# Altium schematic sheet I2C.SchDoc
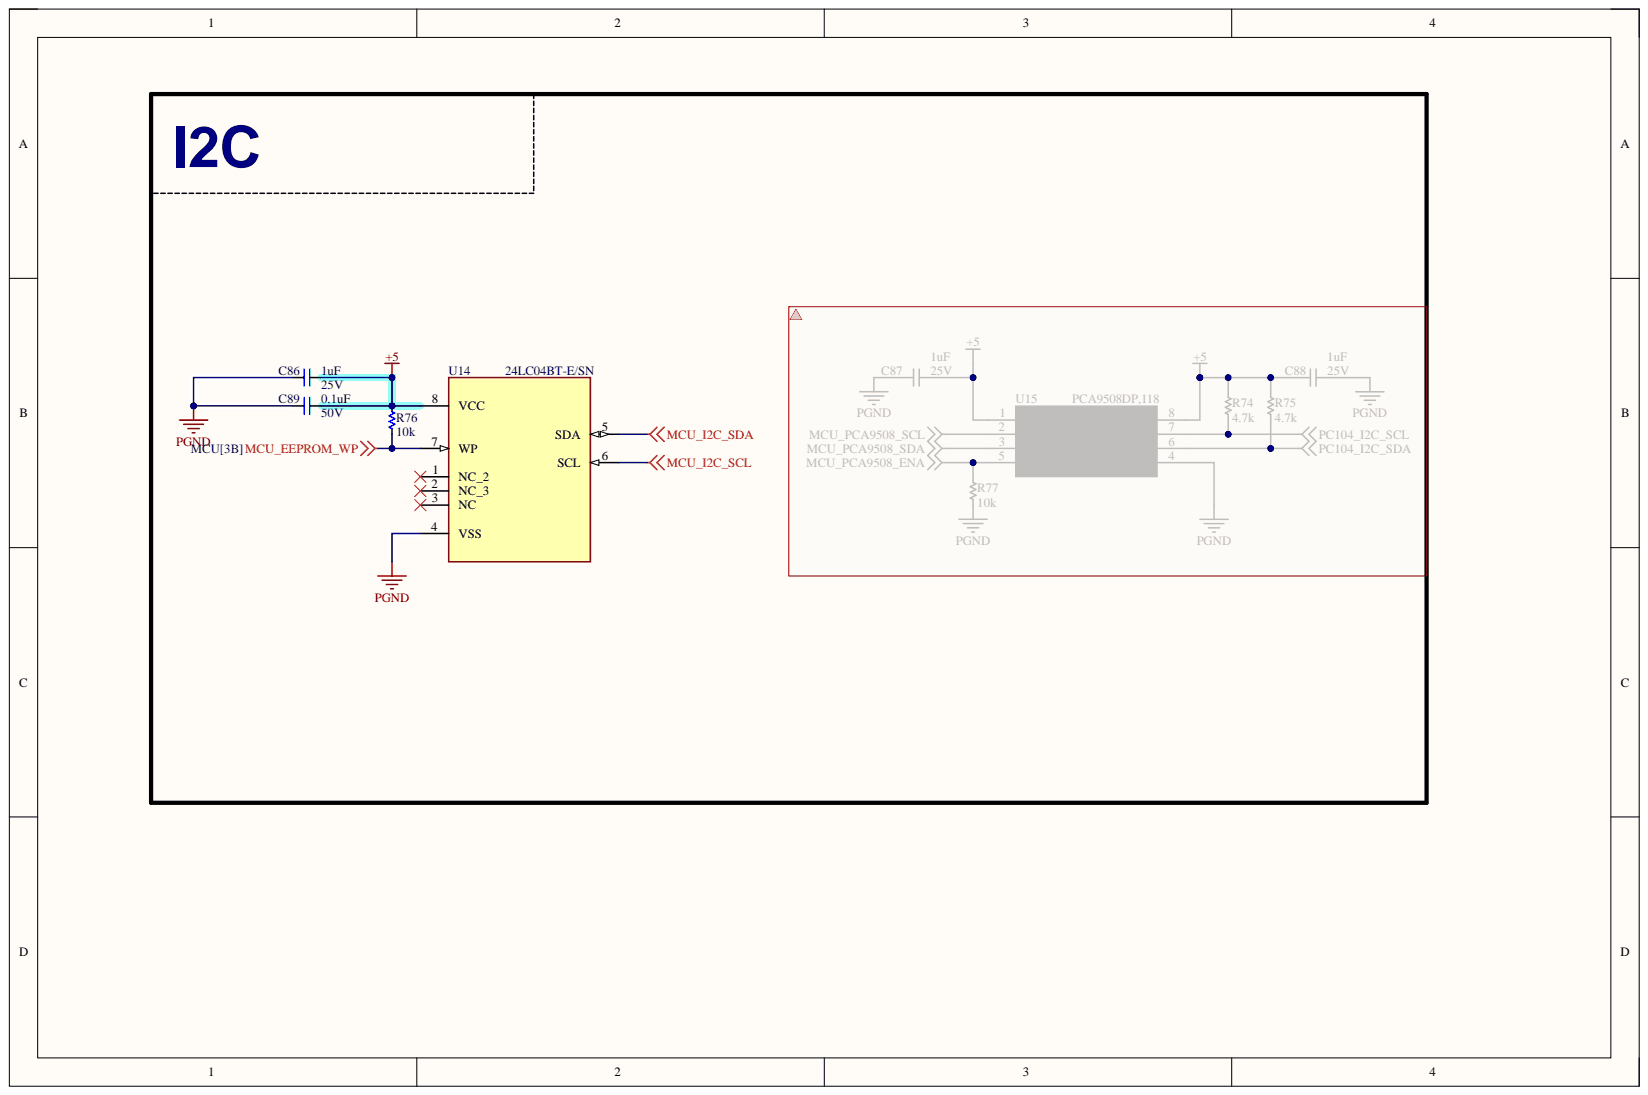
[diagram p0: I2C.SchDoc]

## Parts
C86  1uF  Voltage: 25V  [CAP_C_1608X80]
C89  0.1uF  Voltage: 50V  [CAP_C_1608X80]
R76  10k  [RES_C_1608X60]
U14  24LC04BT-E/SN  [SOIC_127]

## Nets
+5: C86.1 C89.1 R76.1 U14.8(VCC)
MCU_EEPROM_WP: R76.2 U14.7(WP)
MCU_I2C_SCL: U14.6(SCL)
MCU_I2C_SDA: U14.5(SDA)
PGND: C86.2 C89.2 U14.4(VSS)

Not connected: U14 1(NC_2) 2(NC_3) 3(NC)

## Library data of the parts
C86 C89 = _CAP_C_2: CAP; Component Kind: Standard; Library Name: LABLIB.SchLib
R76 = _RES_B_2_2: RES; Component Kind: Standard; Library Name: LABLIB.SchLib
U14 = 24LC04BT-E/SN: Component Kind: Standard; Library Name: KIENASIY123_LIB.IntLib
